# Altium schematic sheet Protection.SchDoc(Protection)
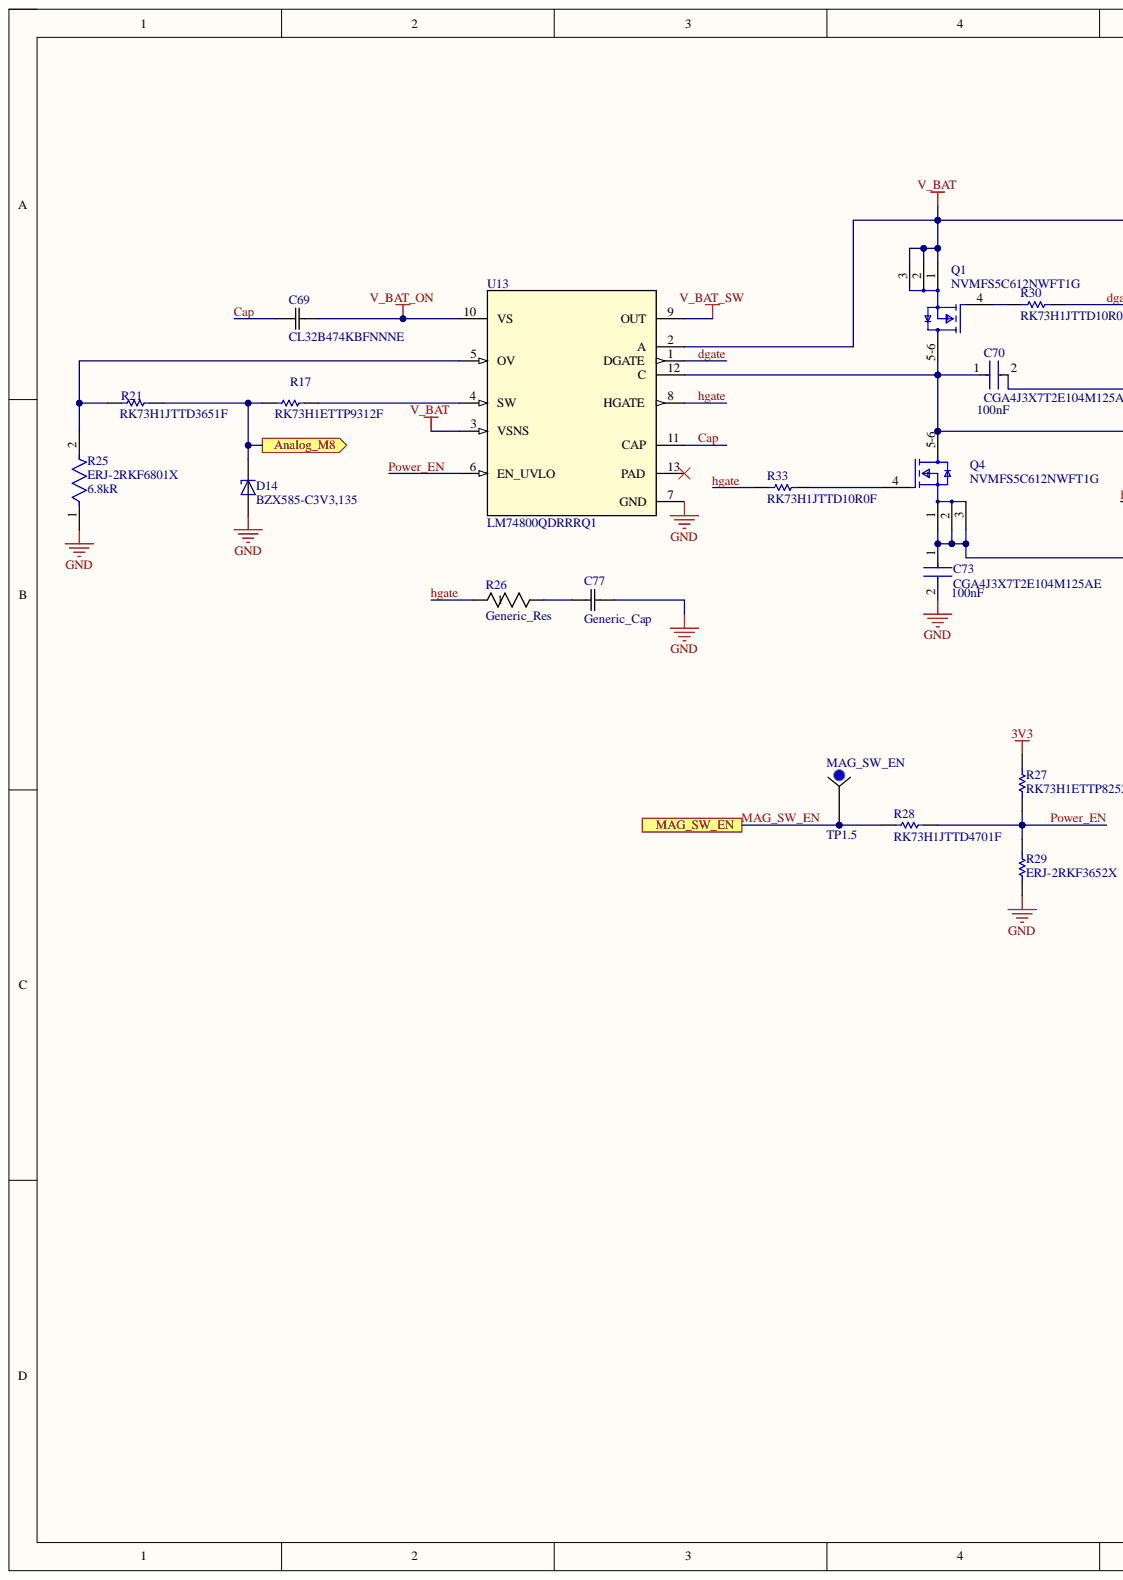
[diagram p0: Protection.SchDoc, left]
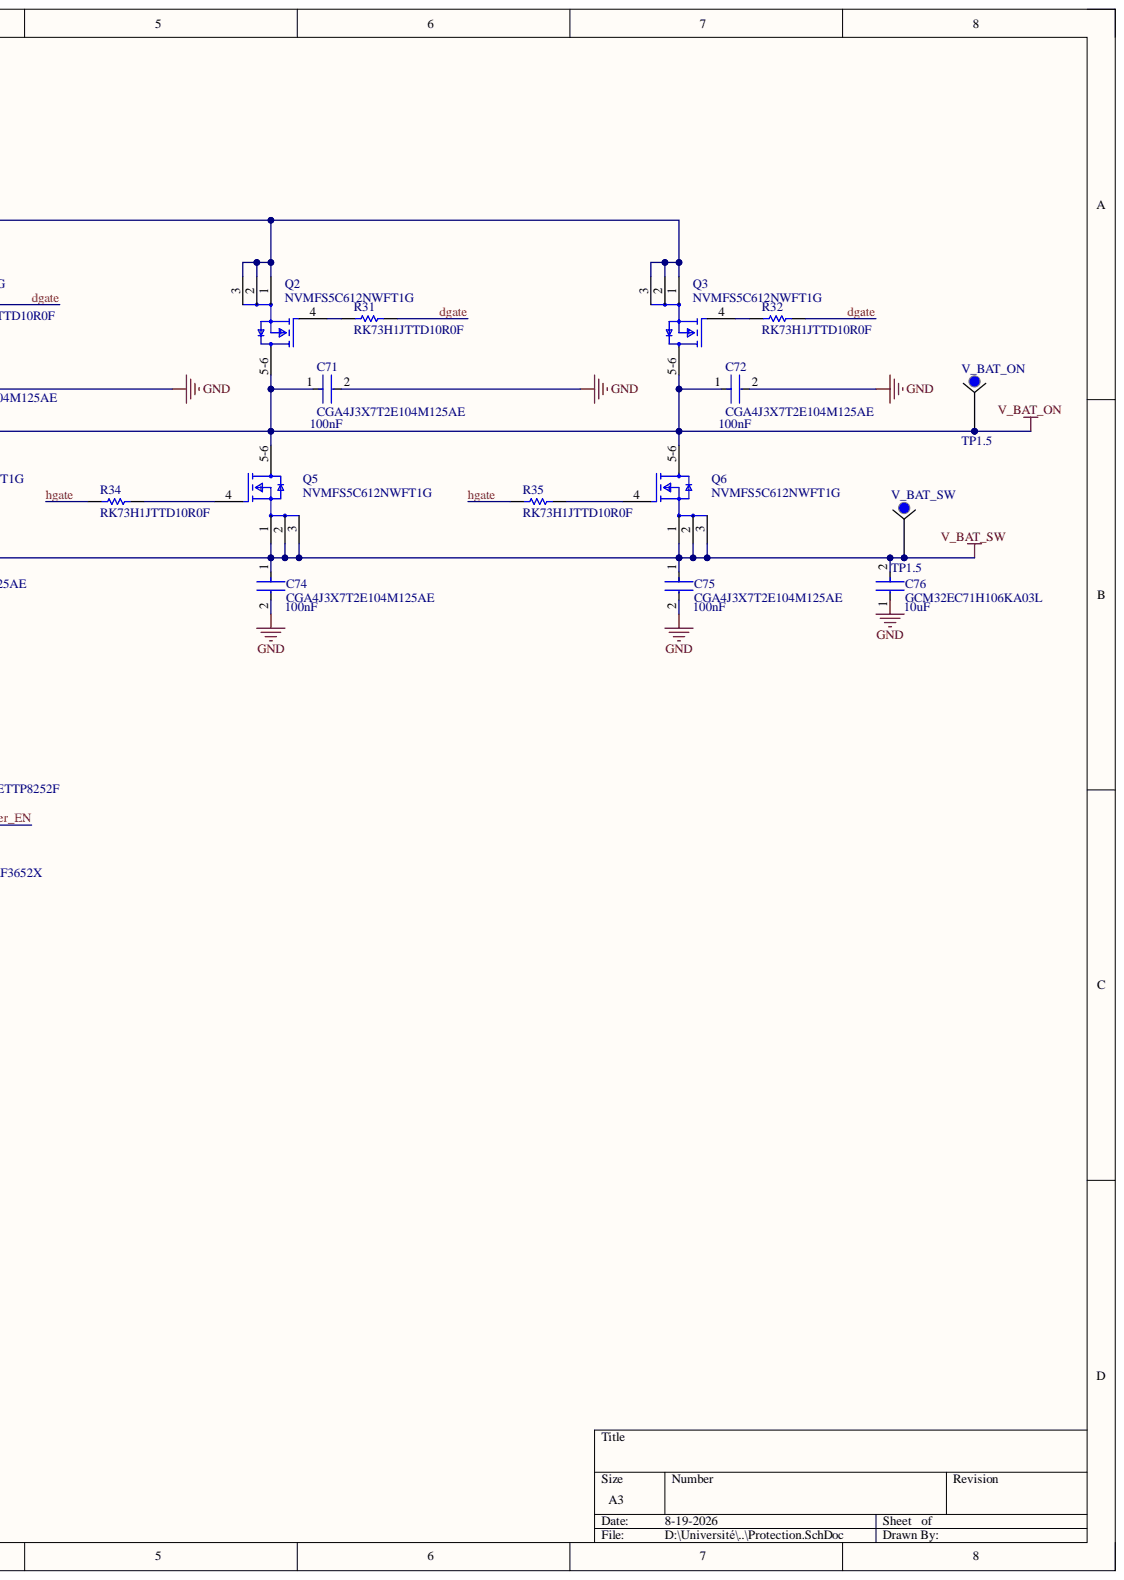
[diagram p1: Protection.SchDoc, right]

## Parts
C69  CL32B474KBFNNNE  [CAP_CL32_SAM-M]
C70  CGA4J3X7T2E104M125AE  Capacitance: 100nF  [FP-CGA4J-IPC_C]  (no BOM)
C71  CGA4J3X7T2E104M125AE  Capacitance: 100nF  [FP-CGA4J-IPC_C]  (no BOM)
C72  CGA4J3X7T2E104M125AE  Capacitance: 100nF  [FP-CGA4J-IPC_C]  (no BOM)
C73  CGA4J3X7T2E104M125AE  Capacitance: 100nF  [FP-CGA4J-IPC_C]  (no BOM)
C74  CGA4J3X7T2E104M125AE  Capacitance: 100nF  [FP-CGA4J-IPC_C]  (no BOM)
C75  CGA4J3X7T2E104M125AE  Capacitance: 100nF  [FP-CGA4J-IPC_C]  (no BOM)
C76  GCM32EC71H106KA03L  Capacitance: 10uF  [FP-GCM32E-0_3-IPC_C]
C77  Generic_Cap  [CAP_2012_TDK-M]  (no BOM)
D14  BZX585-C3V3,135  [DIO_BZX585-C3V3,135]  (no BOM)
MAG_SW_EN  TP1.5  [TP1.5]  (no BOM)
Q1  NVMFS5C612NWFT1G  [FP-507BA-MFG]
Q2  NVMFS5C612NWFT1G  [FP-507BA-MFG]  (no BOM)
Q3  NVMFS5C612NWFT1G  [FP-507BA-MFG]  (no BOM)
Q4  NVMFS5C612NWFT1G  [FP-507BA-MFG]  (no BOM)
Q5  NVMFS5C612NWFT1G  [FP-507BA-MFG]  (no BOM)
Q6  NVMFS5C612NWFT1G  [FP-507BA-MFG]  (no BOM)
R17  RK73H1ETTP9312F  [RESC1005X40X25NL10T10]
R21  RK73H1JTTD3651F  [RESC1608X55X30ML20T10]
R25  ERJ-2RKF6801X  Resistance: 6.8kR  [FP-ERJ2RK-IPC_B]
R26  Generic_Res  [RES_RMCF0805_STP-M]  (no BOM)
R27  RK73H1ETTP8252F  [RESC1005X40X25NL10T10]
R28  RK73H1JTTD4701F  [RESC1608X55X30LL20T10]
R29  ERJ-2RKF3652X  [RESC1005X40X25LL05T05]
R30  RK73H1JTTD10R0F  [RESC1608X55X30NL20T10]
R31  RK73H1JTTD10R0F  [RESC1608X55X30NL20T10]
R32  RK73H1JTTD10R0F  [RESC1608X55X30NL20T10]
R33  RK73H1JTTD10R0F  [RESC1608X55X30NL20T10]
R34  RK73H1JTTD10R0F  [RESC1608X55X30NL20T10]
R35  RK73H1JTTD10R0F  [RESC1608X55X30NL20T10]
U13  LM74800QDRRRQ1  [DRR0012E]
V_BAT_ON  TP1.5  [TP1.5]  (no BOM)
V_BAT_SW  TP1.5  [TP1.5]  (no BOM)

## Ports
Analog_M8, MAG_SW_EN

## Nets
3V3: R27.2
GND: C70.2 C71.2 C72.2 C73.2 C74.2 C75.2 C76.1 C77.2 D14.A R25.1 R29.1 U13.7(GND)
V_BAT: Q1.1 Q1.2 Q1.3 Q2.1 Q2.2 Q2.3 Q3.1 Q3.2 Q3.3 U13.2(A) U13.3(VSNS)
V_BAT_ON: C69.2 C70.1 C71.1 C72.1 Q1.5-6 Q2.5-6 Q3.5-6 Q4.5-6 Q5.5-6 Q6.5-6 U13.10(VS) U13.12(C) V_BAT_ON.1
V_BAT_SW: C73.1 C74.1 C75.1 C76.2 Q4.1 Q4.2 Q4.3 Q5.1 Q5.2 Q5.3 Q6.1 Q6.2 Q6.3 U13.9(OUT) V_BAT_SW.1
Analog_M8: D14.K R17.1 R21.2 ; port Analog_M8
Cap: C69.1 U13.11(CAP)
dgate: R30.2 R31.2 R32.2 U13.1(DGATE)
hgate: R26.1 R33.1 R34.1 R35.1 U13.8(HGATE)
MAG_SW_EN: MAG_SW_EN.1 R28.2 ; port MAG_SW_EN
Power_EN: R27.1 R28.1 R29.2 U13.6(EN_UVLO)
NetC77_1: C77.1 R26.2
NetQ1_4: Q1.4 R30.1
NetQ2_4: Q2.4 R31.1
NetQ3_4: Q3.4 R32.1
NetQ4_4: Q4.4 R33.2
NetQ5_4: Q5.4 R34.2
NetQ6_4: Q6.4 R35.2
NetR17_2: R17.2 U13.4(SW)
NetR21_1: R21.1 R25.2 U13.5(OV)

Not connected: U13 13(PAD)

## Library data of the parts
C69 = CL32B474KBFNNNE: 0.47 µF ±10% 50V Ceramic Capacitor X7R 1210 (3225 Metric); Manufacturer_Name: Samsung; Component Kind: Standard; LCSC_Part_Number: C318787; Library Name: Protection_Lib.SchLib; Manufacturer_Part_Number: CL32B474KBFNNNE; Copyright: Copyright (C) 2025 Ultra Librarian. All rights reserved.
C70-C75 = CMP-08246-000150-1: Cap Ceramic 100nF 250V X7T 20% Pad SMD 0805 +125°C Automotive T/R; Case Code (Imperial): 0805; Case Code (Metric): 2012; Case/Package: 0805; Depth: 1.25mm; Dielectric: X7T; Features: Soft Termination; Height: 1.25mm; LCSC_Part_Number: C2170948; Length: 2mm; Library Name: Altium Content Vault; Max Operating Temperature: 125°C; Min Operating Temperature: -55°C; Mount: Surface Mount; Package Quantity: 1; Packaging: Tape and Reel; Radiation Hardening: No; Ratings: AEC-Q200; REACH SVHC: No SVHC; Termination: SMD/SMT; Thickness: 0.049inch; Tolerance: 20%; Voltage Rating: 250V; Voltage Rating (DC): 250V; Weight: 0.000194oz; Width: 0.049inch
C76 = CMP-06035-042741-1: Ceramic Capacitor for Automotive 10uF ±10% 50VDC X7S 1210 Embossed T/R; Voltage Rating: 50V; Case Code (Imperial): 1210; Case Code (Metric): 3225; Case/Package: 1210; Component Kind: Standard; Depth: 2.5mm; Dielectric: X7S; Height: 2.5mm; LCSC_Part_Number: C126612; Length: 3.2mm; Library Name: Altium Content Vault; Max Operating Temperature: 125°C; Min Operating Temperature: -55°C; Mount: Surface Mount; Package Quantity: 1000; Packaging: Tape and Reel; Ratings: AEC-Q200; Termination: SMD/SMT; Thickness: 0.106inch; Tolerance: 10%; Voltage Rating (DC): 50V; Weight: 0.00388oz; Width: 2.5mm
C77 = Generic_Cap: A generic capacitor with 0805 footprint; LCSC_Part_Number: A_verifier; Library Name: Protection_Lib.SchLib; Copyright: Copyright (C) 2026 Ultra Librarian. All rights reserved.
D14 = BZX585-C3V3,135: Zener Diode Single 3.3V 5% 95Ohm 300mW Automotive 2-Pin SOD-523 T/R; MF: Nexperia; Check_prices: https://www.snapeda.com/parts/BZX585-C3V3,135/Nexperia/view-part/?ref=eda; LCSC_Part_Number: C550650; Library Name: Protection_Lib.SchLib; MP: BZX585-C3V3,135; Package: SC-79-2 Nexperia USA Inc.; SnapEDA_Link: https://www.snapeda.com/parts/BZX585-C3V3,135/Nexperia/view-part/?ref=snap
MAG_SW_EN V_BAT_ON V_BAT_SW = TP1.5: Testpoint 1.5mm; Library Name: PCB_Power.SchLib
Q1-Q6 = CMP-00278-101817606-1: NVMFS5C612NWFT1G Mosfet, N-Ch, 60V, 225A, 175Deg C, 167W Rohs Compliant: Yes; Manufacturer Lifecycle Status: ACTIVE; Continuous Drain Current (ID): 34A; Drain to Source Resistance: 1.35mΩ; Gate to Source Voltage (Vgs): 20V; Max Junction Temperature (Tj): 175°C; Number of Channels: 1; Power Dissipation: 3.8W; Turn-Off Delay Time: 46.5ns; Turn-On Delay Time: 13.2ns; Drain to Source Breakdown Voltage: 60V; Drain to Source Voltage (Vdss): 60V; Height: 1.1mm; LCSC_Part_Number: C900446; Library Name: Altium Content Vault; Lifecycle Status: Production; Max Operating Temperature: 175°C; Min Operating Temperature: -55°C; NexarPartId: 101817606; RoHS: Compliant; Schedule B: 8541290080, 8541290080
  Q1: Component Kind: Standard
R17 = CMP-2002-04011-1: Manufacturer: KOA Speer; Component Kind: Standard; LCSC_Part_Number: C830273; Library Name: Altium Content Vault; Part Number: RK73H1ETTP9312F
R21 = CMP-2000-01454-1: Manufacturer: KOA Speer; Component Kind: Standard; LCSC_Part_Number: C276205; Library Name: Altium Content Vault; Part Number: RK73H1JTTD3651F
R25 = CMP-1011-00604-3: RES SMD 6.8K OHM 1% 1/10W 0402; Max Power Dissipation: 100mW; Temperature Coefficient: 100ppm/°C; Voltage Rating: 50V; Case Code (Imperial): 0402; Case Code (Metric): 1005; Case/Package: 0402; Component Kind: Standard; Composition: Thick Film; Contact Plating: Tin; Depth: 0.5mm; Features: Automotive AEC-Q200; Height: 0.35mm; LCSC_Part_Number: C409924; Lead Free: Lead Free; Length: 1mm; Library Name: Altium Content Vault; Max Operating Temperature: 155°C; Military Standard: Not; Min Operating Temperature: -55°C; Mount: Surface Mount; Number of Pins: 2; Number of Terminations: 2; Package Quantity: 1; Packaging: Tape and Reel; Power Rating: 100mW; Radiation Hardening: No; REACH SVHC: No SVHC; Termination: SMD/SMT; Tolerance: 1%; Voltage Rating (DC): 50V; Width: 0.5mm
R26 = Generic_Res: A generic resistor with a 0805 footprint; LCSC_Part_Number: A_verifier; Library Name: Protection_Lib.SchLib; Copyright: Copyright (C) 2026 Ultra Librarian. All rights reserved.
R27 = CMP-2002-03825-1: Manufacturer: KOA Speer; Component Kind: Standard; LCSC_Part_Number: C830263; Library Name: Altium Content Vault; Part Number: RK73H1ETTP8252F
R28 = CMP-2000-01528-1: Manufacturer: KOA Speer; Component Kind: Standard; LCSC_Part_Number: C130236; Library Name: Altium Content Vault; Part Number: RK73H1JTTD4701F
R29 = CMP-1011-00686-2: Manufacturer: Panasonic; Component Kind: Standard; LCSC_Part_Number: C2986586; Library Name: Altium Content Vault; Part Number: ERJ-2RKF3652X
R30-R35 = CMP-2000-01115-1: Manufacturer: KOA Speer; Component Kind: Standard; LCSC_Part_Number: C173372; Library Name: Altium Content Vault; Part Number: RK73H1JTTD10R0F
U13 = LM74800QDRRRQ1: 3-V to 65-V, automotive ideal diode controller driving back to back NFETs 12-WSON -40 to 125; Manufacturer_Name: Texas Instruments; Component Kind: Standard; LCSC_Part_Number: C3215600; Library Name: Protection_Lib.SchLib; Manufacturer_Part_Number: LM74800QDRRRQ1; RefDes: RefDes; TYPE: TYPE; Copyright: Copyright (C) 2026 Ultra Librarian. All rights reserved.
